# Altium schematic sheet 3-2-DO.SchDoc(3-2-DO)
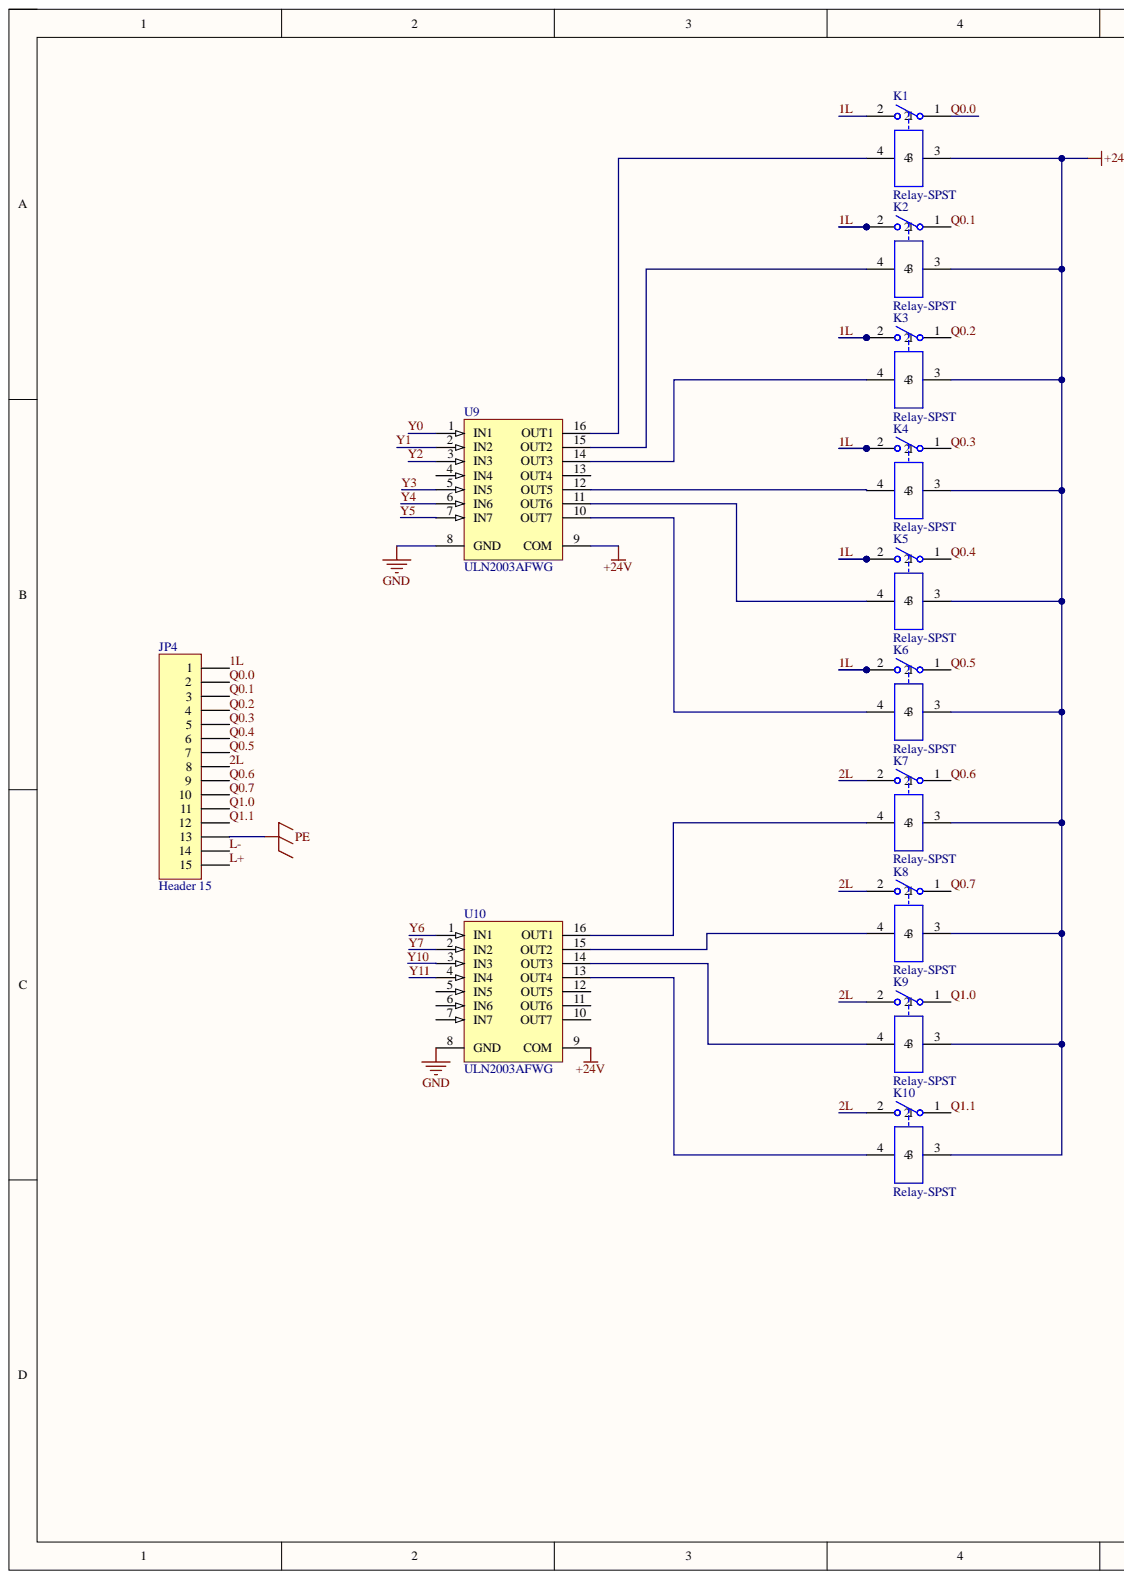
[diagram p0: 3-2-DO.SchDoc, left]
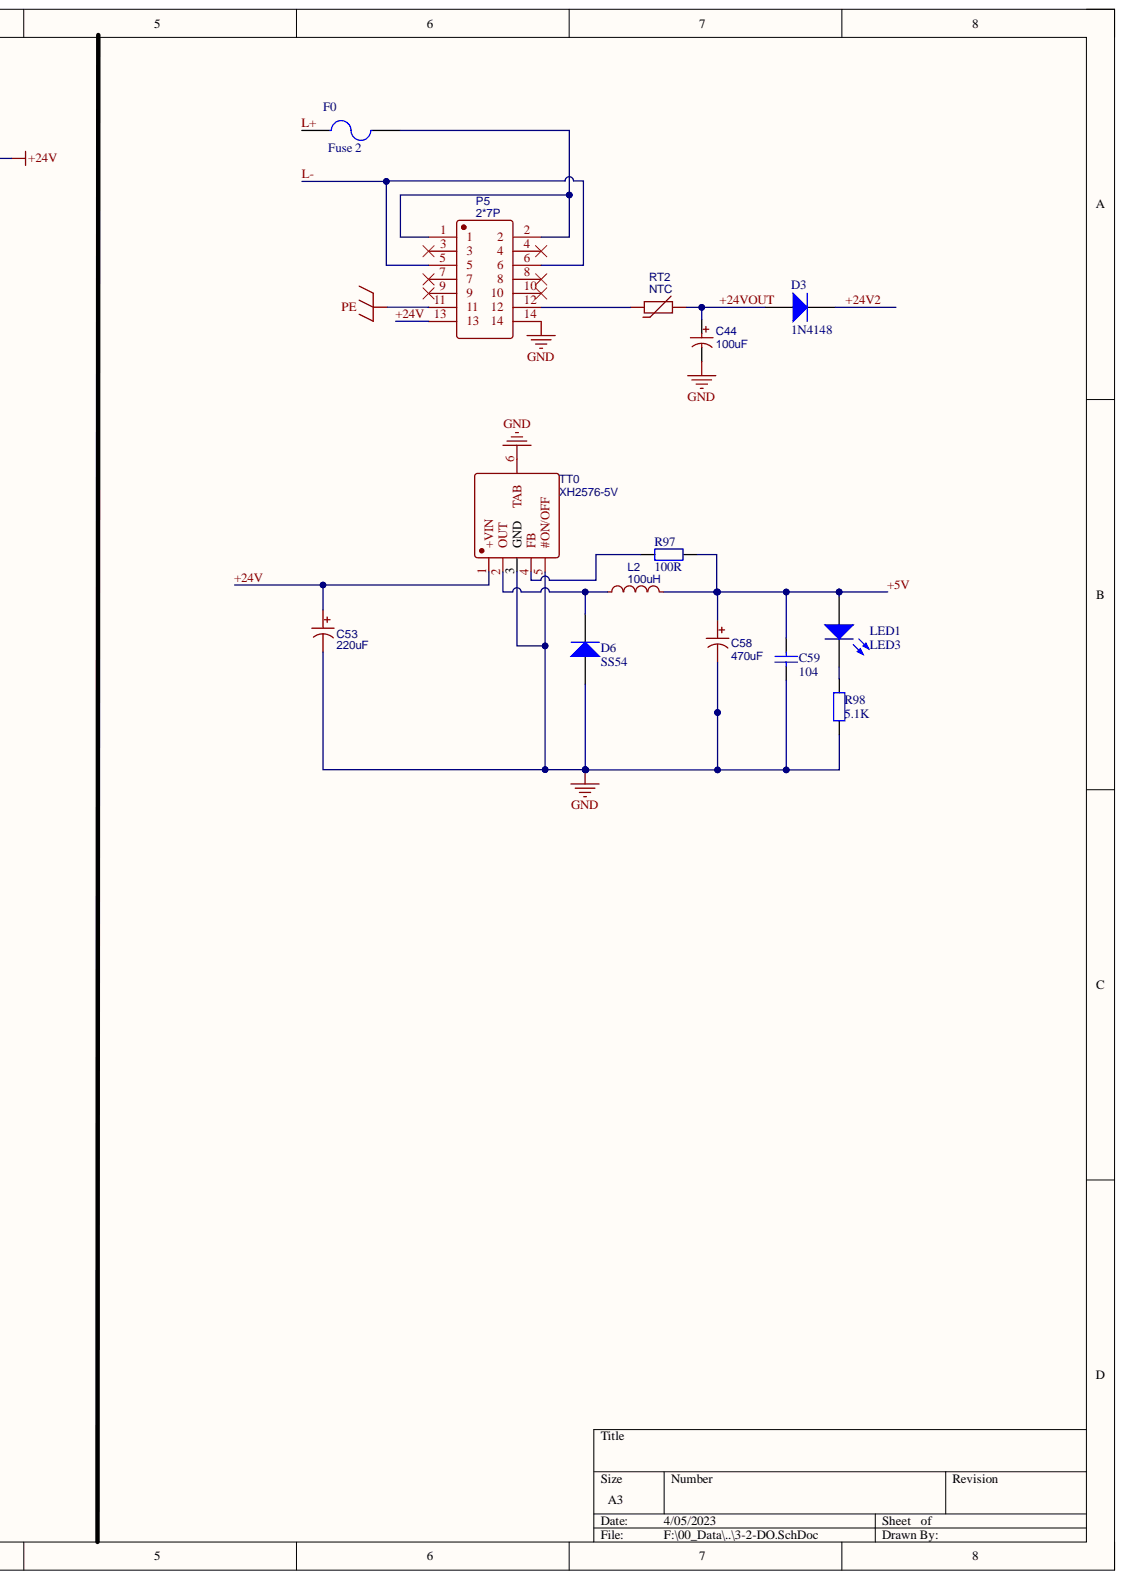
[diagram p1: 3-2-DO.SchDoc, right]

## Parts
C44  100uF  [CAP-SMD_BD6.3-L6.6-W6.6-FD]
C53  220uF  [CAP-SMD_BD10.0-L10.3-W10.3-FD]
C58  470uF  [CAP-SMD_BD10.0-L10.3-W10.3-FD]
C59  104  [0805_C]
D3  1N4148  [SMC(DO-214AB)_S1]
D6  SS54  [SMC(DO-214AB)_S1]
F0  Fuse 2  [PIN-W2/E2.8]
JP4  Header 15  [HDR1X15]
K1  Relay-SPST  [Y32F-SS-105DM]
K2  Relay-SPST  [Y32F-SS-105DM]
K3  Relay-SPST  [Y32F-SS-105DM]
K4  Relay-SPST  [Y32F-SS-105DM]
K5  Relay-SPST  [Y32F-SS-105DM]
K6  Relay-SPST  [Y32F-SS-105DM]
K7  Relay-SPST  [Y32F-SS-105DM]
K8  Relay-SPST  [Y32F-SS-105DM]
K9  Relay-SPST  [Y32F-SS-105DM]
K10  Relay-SPST  [Y32F-SS-105DM]
L2  100uH  [IND-TH_BD10.0-P5.00-D0.7]
LED1  LED3  [3.5X2.8X1.9]
P5  2*7P  [HDR-TH_14P-P2.54-V-F-R2-C7-S2.54-1]
R97  100R  [0603_R]
R98  5.1K  [0603_R]
RT2  NTC  [RES-TH_L10.5-W5.5-P7.50-D0.6-S5.50]
TT0  XH2576-5V  [TO-263-5_L10.2-W8.9-P1.70-BR]
U9  ULN2003AFWG  [SOIC-16_150mil]
U10  ULN2003AFWG  [SOIC-16_150mil]

## Nets
GND: C44.2 C53.2 C58.2 C59.2 D6.1 P5.14 R98.2 TT0.3(GND) TT0.5(#ON/OFF) TT0.6(TAB) U9.8(GND) U10.8(GND)
PE: JP4.13 P5.11
1L: JP4.1 K1.2 K2.2 K3.2 K4.2 K5.2 K6.2
2L: JP4.8 K7.2 K8.2 K9.2 K10.2
+5V: C58.1 C59.1 L2.2 LED1.1 R97.1
+24V: C53.1 K1.3 K2.3 K3.3 K4.3 K5.3 K6.3 K7.3 K8.3 K9.3 K10.3 P5.13 TT0.1(+VIN) U9.9(COM) U10.9(COM)
+24V2: D3.2
+24VOUT: C44.1 D3.1 RT2.2
L+: F0.1 JP4.15
L-: JP4.14 P5.5 P5.6
Q0.0: JP4.2 K1.1
Q0.1: JP4.3 K2.1
Q0.2: JP4.4 K3.1
Q0.3: JP4.5 K4.1
Q0.4: JP4.6 K5.1
Q0.5: JP4.7 K6.1
Q0.6: JP4.9 K7.1
Q0.7: JP4.10 K8.1
Q1.0: JP4.11 K9.1
Q1.1: JP4.12 K10.1
Y0: U9.1(IN1)
Y1: U9.2(IN2)
Y2: U9.3(IN3)
Y3: U9.5(IN5)
Y4: U9.6(IN6)
Y5: U9.7(IN7)
Y6: U10.1(IN1)
Y7: U10.2(IN2)
Y10: U10.3(IN3)
Y11: U10.4(IN4)
NetD6_2: D6.2 L2.1 TT0.2(OUT)
NetF0_2: F0.2 P5.1 P5.2
NetK1_4: K1.4 U9.16(OUT1)
NetK2_4: K2.4 U9.15(OUT2)
NetK3_4: K3.4 U9.14(OUT3)
NetK4_4: K4.4 U9.12(OUT5)
NetK5_4: K5.4 U9.11(OUT6)
NetK6_4: K6.4 U9.10(OUT7)
NetK7_4: K7.4 U10.16(OUT1)
NetK8_4: K8.4 U10.15(OUT2)
NetK9_4: K9.4 U10.14(OUT3)
NetK10_4: K10.4 U10.13(OUT4)
NetLED1_2: LED1.2 R98.1
NetP5_12: P5.12 RT2.1
NetR97_2: R97.2 TT0.4(FB)

Not connected: P5 3 4 7 8 9 10; U9 4(IN4) 13(OUT4); U10 5(IN5) 6(IN6) 7(IN7) 10(OUT7) 11(OUT6) 12(OUT5)

## Library data of the parts
C44 = 100uF: BOM_Manufacturer: PANASONIC; BOM_Manufacturer Part: EEEFK1V101XP; Contributor: LCSC; nameAlias: Capacitance; BOM_JLCPCB Part Class: Extended Part; BOM_Supplier: LCSC; BOM_Supplier Part: C178560; Component Kind: Standard; spicePre: C; spiceSymbolName: EEEFK1V101XP
C53 C58 = 220uF: BOM_Manufacturer: HONOR; BOM_Manufacturer Part: RVT1H221M1010; nameAlias: Capacitance; BOM_JLCPCB Part Class: Extended Part; BOM_Supplier: LCSC; BOM_Supplier Part: C3358; Component Kind: Standard; spicePre: C; spiceSymbolName: RVT1H221M1010-C3358
C59 = Cap_0805: 0805贴片电容; Component Kind: Standard; Library Name: 02-Miscellaneous_Devices_ZSF_Library.IntLib; Package: 0805; Value: 104; 常见贴片电容尺寸图: https://wenku.baidu.com/view/21d5206100f69e3143323968011ca300a6c3f6b2.html
D3 D6 = SS36-E3/57T_SMC(DO-214AB): 二极管; Component Kind: Standard; ComponentLink1Description: 供应商链接; ComponentLink1URL: http://www.szlcsc.com/product/details_36698.html; Library Name: 02-Miscellaneous_Devices_ZSF_Library.IntLib; Package: SMC(DO-214AB); Supplier: LC; SuppliersPartNumber: C35722
F0 = Fuse 2: Fuse; Component Kind: Standard; LatestRevisionDate: 17-Jul-2002; LatestRevisionNote: Re-released for DXP Platform.; Library Name: Miscellaneous Devices.IntLib; PackageDescription: Thru-Hole; 2 Leads; PackageReference: PIN-W2/E2.8; Published: 8-Jun-2000; Simulation: FUSE; Publisher: Altium Limited
JP4 = Header 15: Header, 15-Pin; Component Kind: Standard; LatestRevisionDate: 17-Jul-2002; LatestRevisionNote: Re-released for DXP Platform.; Library Name: Miscellaneous Connectors.IntLib; Signal Integrity: Connector; Publisher: Altium Limited
K1-K10 = Relay-SPST: Single-Pole Single-Throw Relay; Component Kind: Standard; Library Name: 02-Miscellaneous_Devices_ZSF_Library.IntLib; 购买链接: https://detail.1688.com/offer/791010756.html?spm=a2615.7691456.oldlist.20.2427739fzmpdcl
L2 = 100uH: BOM_Manufacturer: FH; BOM_Manufacturer Part: VLU0810-101K; nameAlias: Inductance; BOM_JLCPCB Part Class: Extended Part; BOM_Supplier: LCSC; BOM_Supplier Part: C72630; Component Kind: Standard; spicePre: L; spiceSymbolName: VLU0810-101K
LED1 = LED3: Typical BLUE SiC LED; Component Kind: Standard; DatasheetVersion: 16-Apr-2002; LatestRevisionDate: 17-Dec-2003; LatestRevisionNote: PCB Footprint replaced.; Library Name: Miscellaneous Devices.IntLib; PackageDescription: SM LED; 2 C-Bend Leads; Body 3.5 x 2.8 x 1.9 mm, inc leads (LxWxH typ); PackageReference: 3.5X2.8X1.9; PackageVersion: 16-Apr-2002; Published: 8-Jun-2000; Signal Integrity: LED; Simulation: LED3; Publisher: Altium Limited
P5 = 220S-2*7P H=8.5MM Ytype Gold-plated: BOM_Manufacturer: Ckmtw; Contributor: LCSC; BOM_JLCPCB Part Class: Extended Part; BOM_Manufacturer Part: 220S-2*7P H=8.5MM Ytype Gold-plated; BOM_Supplier: LCSC; BOM_Supplier Part: C124424; Component Kind: Standard; spicePre: H; spiceSymbolName: 220S-2*7P H=8.5MM Ytype Gold-plated
R97 R98 = Res_0603: 贴片电阻; Component Kind: Standard; ComponentLink1Description: 供应商链接; ComponentLink1URL: http://www.szlcsc.com/product/details_21903.html; Library Name: 02-Miscellaneous_Devices_ZSF_Library.IntLib; Package: 0603; Supplier: LC; SuppliersPartNumber: C21189
RT2 = NTC: BOM_Manufacturer: RUILON; BOM_Manufacturer Part: NTC 5D-9; nameAlias: Value; BOM_JLCPCB Part Class: Extended Part; BOM_Supplier: LCSC; BOM_Supplier Part: C3789; Component Kind: Standard; spicePre: R; spiceSymbolName: NTC 5D-9
TT0 = XH2576-12: BOM_Manufacturer: XINLUDA; Contributor: LCSC; BOM_JLCPCB Part Class: Extended Part; BOM_Manufacturer Part: XH2576-12; BOM_Supplier: LCSC; BOM_Supplier Part: C609789; Component Kind: Standard; spicePre: U; spiceSymbolName: XH2576-12
U9 U10 = ULN2003AFWG: 大电流驱动; Component Kind: Standard; ComponentLink1Description: 供应商链接; ComponentLink1URL: http://www.szlcsc.com/product/details_5880.html; Library Name: 02-Miscellaneous_Devices_ZSF_Library.IntLib; Package: SOIC-16_150mil; Supplier: LC; SuppliersPartNumber: C5437
